# Altium schematic sheet CV-DAC.SchDoc
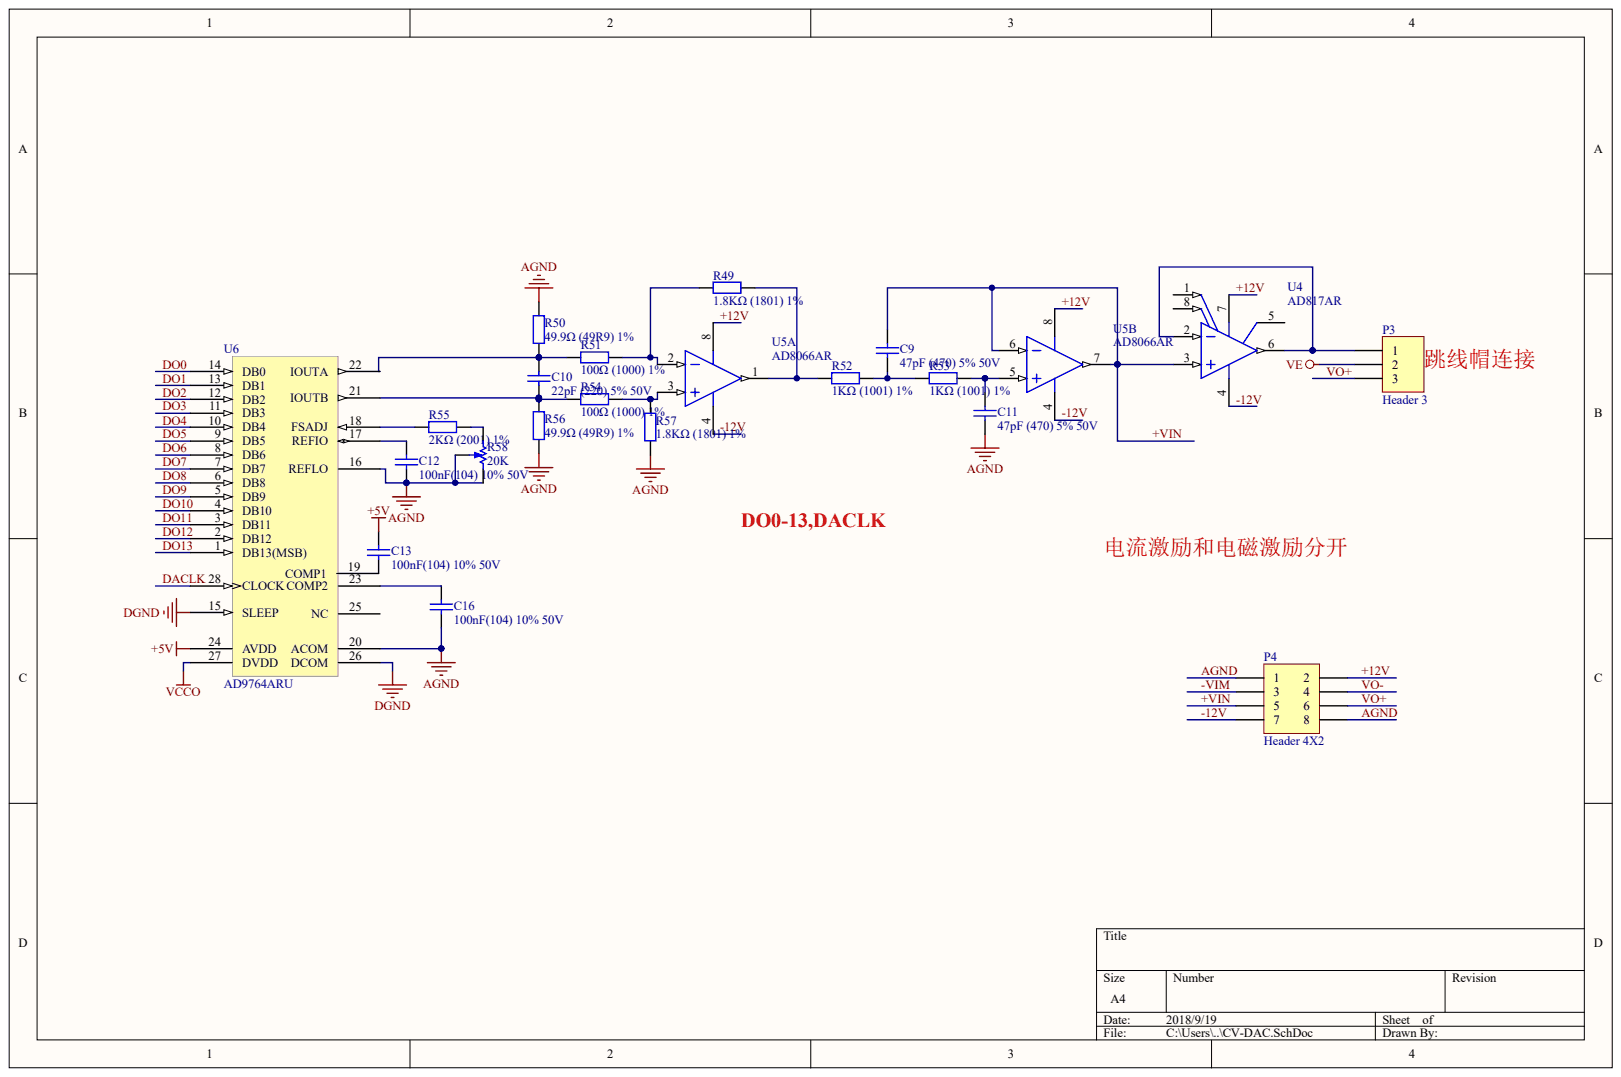
[diagram p0: CV-DAC.SchDoc]

## Parts
C9  47pF (470) 5% 50V
C10  22pF (220) 5% 50V
C11  47pF (470) 5% 50V
C12  100nF(104) 10% 50V
C13  100nF(104) 10% 50V
C16  100nF(104) 10% 50V
P3  Header 3
P4  Header 4X2
R49  1.8KΩ (1801) ±1%
R50  49.9Ω (49R9) ±1%
R51  100Ω (1000) ±1%
R52  1KΩ (1001) ±1%
R53  1KΩ (1001) ±1%
R54  100Ω (1000) ±1%
R55  2KΩ (2001) ±1%
R56  49.9Ω (49R9) ±1%
R57  1.8KΩ (1801) ±1%
R58  20K
U4  AD817AR
U5  AD8066AR  (parts A,B)
U6  AD9764ARU

## Nets
+5V: C13.2 U6.24(AVDD)
DGND: U6.15(SLEEP) U6.26(DCOM)
VCCO: U6.27(DVDD)
VE: P3.2
+12V: P4.2 U4.7 U5.8
+VIN: C9.2 P4.5 U4.3 U5.6 U5.7
-12V: P4.7 U4.4 U5.4
-VIM: P4.3
AGND: C11.2 C12.1 C16.1 P4.1 P4.8 R50.2 R56.1 R57.1 R58.CW R58.W U6.16(REFLO) U6.20(ACOM)
DACLK: U6.28(CLOCK)
DO0: U6.14(DB0)
DO1: U6.13(DB1)
DO2: U6.12(DB2)
DO3: U6.11(DB3)
DO4: U6.10(DB4)
DO5: U6.9(DB5)
DO6: U6.8(DB6)
DO7: U6.7(DB7)
DO8: U6.6(DB8)
DO9: U6.5(DB9)
DO10: U6.4(DB10)
DO11: U6.3(DB11)
DO12: U6.2(DB12)
DO13: U6.1(DB13(MSB))
VO+: P3.3 P4.6
VO-: P4.4
NetC9_1: C9.1 R52.2 R53.2
NetC10_1: C10.1 R54.1 R56.2 U6.21(IOUTB)
NetC10_2: C10.2 R50.1 R51.1 U6.22(IOUTA)
NetC11_1: C11.1 R53.1 U5.5
NetC12_2: C12.2 U6.17(REFIO)
NetC13_1: C13.1 U6.19(COMP1)
NetC16_2: C16.2 U6.23(COMP2)
NetP3_1: P3.1 U4.2 U4.6
NetR49_1: R49.1 R51.2 U5.2
NetR49_2: R49.2 R52.1 U5.1
NetR54_2: R54.2 R57.2 U5.3
NetR55_1: R55.1 U6.18(FSADJ)
NetR55_2: R55.2 R58.CCW

Not connected: U4 1 5 8; U6 25(NC)

## Library data of the parts
U4 = (no symbol name): Generic Part Number: AD817; Manufacturer: Analog Devices; Amplifiers Per Package: 1; Input Bias Current: 3.3uA; Input Offset Voltage: 500uV; Iq/Amp: 7.5mA; Slew Rate: 350V/us; Small Signal Bandwidth: 50MHz; V Span Max: 36V; V Span Min: 5V; DatasheetVersion: Rev. B; PackageVersion: 060506-A; PackageReference: R-8; PackageDescription: 8-Pin Standard Small Outline Package, Body 3.9 x 4.9 mm, Pitch 1.27 mm; Supplier 1: Digi-Key; Supplier Part Number 1: AD817AR-ND; Mounting Technology: Surface Mount; Code_JEDEC: MS-012-AA; PartNumber: AD817AR; ComponentLink1Description: Manufacturer URL; ComponentLink1URL: http://www.analog.com/en/index.html; ComponentLink2Description: Datasheet; ComponentLink2URL: http://www.analog.com/static/imported-files/data_sheets/AD817.pdf; ComponentLink3Description: Package Specification; ComponentLink3URL: http://www.analog.com/static/imported-files/packages/PKG_PDF/SOIC_Narrow%20(R)/R_8.pdf
U5 = (no symbol name): Generic Part Number: AD8066; Manufacturer: Analog Devices; Amplifiers Per Package: 2; Input Bias Current: 2pA; Input Offset Voltage: 400uV; Iq/Amp: 7.4mA; Slew Rate: 180V/us; Small Signal Bandwidth: 145MHz; V Span Max: 24V; V Span Min: 5V; DatasheetVersion: Rev. J; PackageVersion: 060506-A; PackageReference: R-8; PackageDescription: 8-Pin Standard Small Outline Package, Body 3.9 x 4.9 mm, Pitch 1.27 mm; Supplier 1: Digi-Key; Supplier Part Number 1: AD8066AR-ND; Mounting Technology: Surface Mount; Code_JEDEC: MS-012-AA; PartNumber: AD8066AR; ComponentLink1Description: Manufacturer URL; ComponentLink1URL: http://www.analog.com/en/index.html; ComponentLink2Description: Datasheet; ComponentLink2URL: http://www.analog.com/static/imported-files/data_sheets/AD8065_8066.pdf; ComponentLink3Description: Package Specification; ComponentLink3URL: http://www.analog.com/static/imported-files/packages/PKG_PDF/SOIC_Narrow%20(R)/R_8.pdf; ComponentLink4Description: Reference Circuit; ComponentLink4URL: http://www.analog.com/en/all-operational-amplifiers-op-amps/operational-amplifiers-op-amps/ad8066/products/product.html#product-recommendations
C9-C13 C16 P3 P4 R49-R58 U6 = (no symbol name)
  C9 C11: ComponentLink1Description: 供应商链接; ComponentLink1URL: http://www.szlcsc.com/product/details_15529.html; Package: 0805; Supplier: LC; SuppliersPartNumber: C14857
  C10: ComponentLink1Description: 供应商链接; ComponentLink1URL: http://www.szlcsc.com/product/details_2156.html; Package: 0805; Supplier: LC; SuppliersPartNumber: C1804
  C12 C13 C16: ComponentLink1Description: 供应商链接; ComponentLink1URL: http://www.szlcsc.com/product/details_50686.html; Package: 0805; Supplier: LC; SuppliersPartNumber: C49678
  P3 P4: LatestRevisionDate: 17-Jul-2002; LatestRevisionNote: Re-released for DXP Platform.
  R49 R57: ComponentLink1Description: 供应商链接; ComponentLink1URL: http://www.szlcsc.com/product/details_18086.html; Package: 0805; Supplier: LC; SuppliersPartNumber: C17398
  R50 R56: ComponentLink1Description: 供应商链接; ComponentLink1URL: http://www.szlcsc.com/product/details_18408.html; Package: 0805; Supplier: LC; SuppliersPartNumber: C17720
  R51 R54: ComponentLink1Description: 供应商链接; ComponentLink1URL: http://www.szlcsc.com/product/details_18096.html; Package: 0805; Supplier: LC; SuppliersPartNumber: C17408
  R52 R53: ComponentLink1Description: 供应商链接; ComponentLink1URL: http://www.szlcsc.com/product/details_18201.html; Package: 0805; Supplier: LC; SuppliersPartNumber: C17513
  R55: ComponentLink1Description: 供应商链接; ComponentLink1URL: http://www.szlcsc.com/product/details_18292.html; Package: 0805; Supplier: LC; SuppliersPartNumber: C17604
  R58: Published: 17-Dec-2003; DatasheetVersion: Oct-2003; PackageReference: POT4MM-2; PackageDescription: Square Trimming Potentiometer; ComponentLink1Description: Manufacturer Link; ComponentLink1URL: http://bourns.com/2/search.html
  U6: Manufacturer_Part_Number: AD9764ARU; Vendor: Vendor; Value: Value; Type: Type; RefDes: Refdes
  P3 P4 R58: Publisher: Altium Limited
